# Altium schematic sheet analog_osc.SchDoc
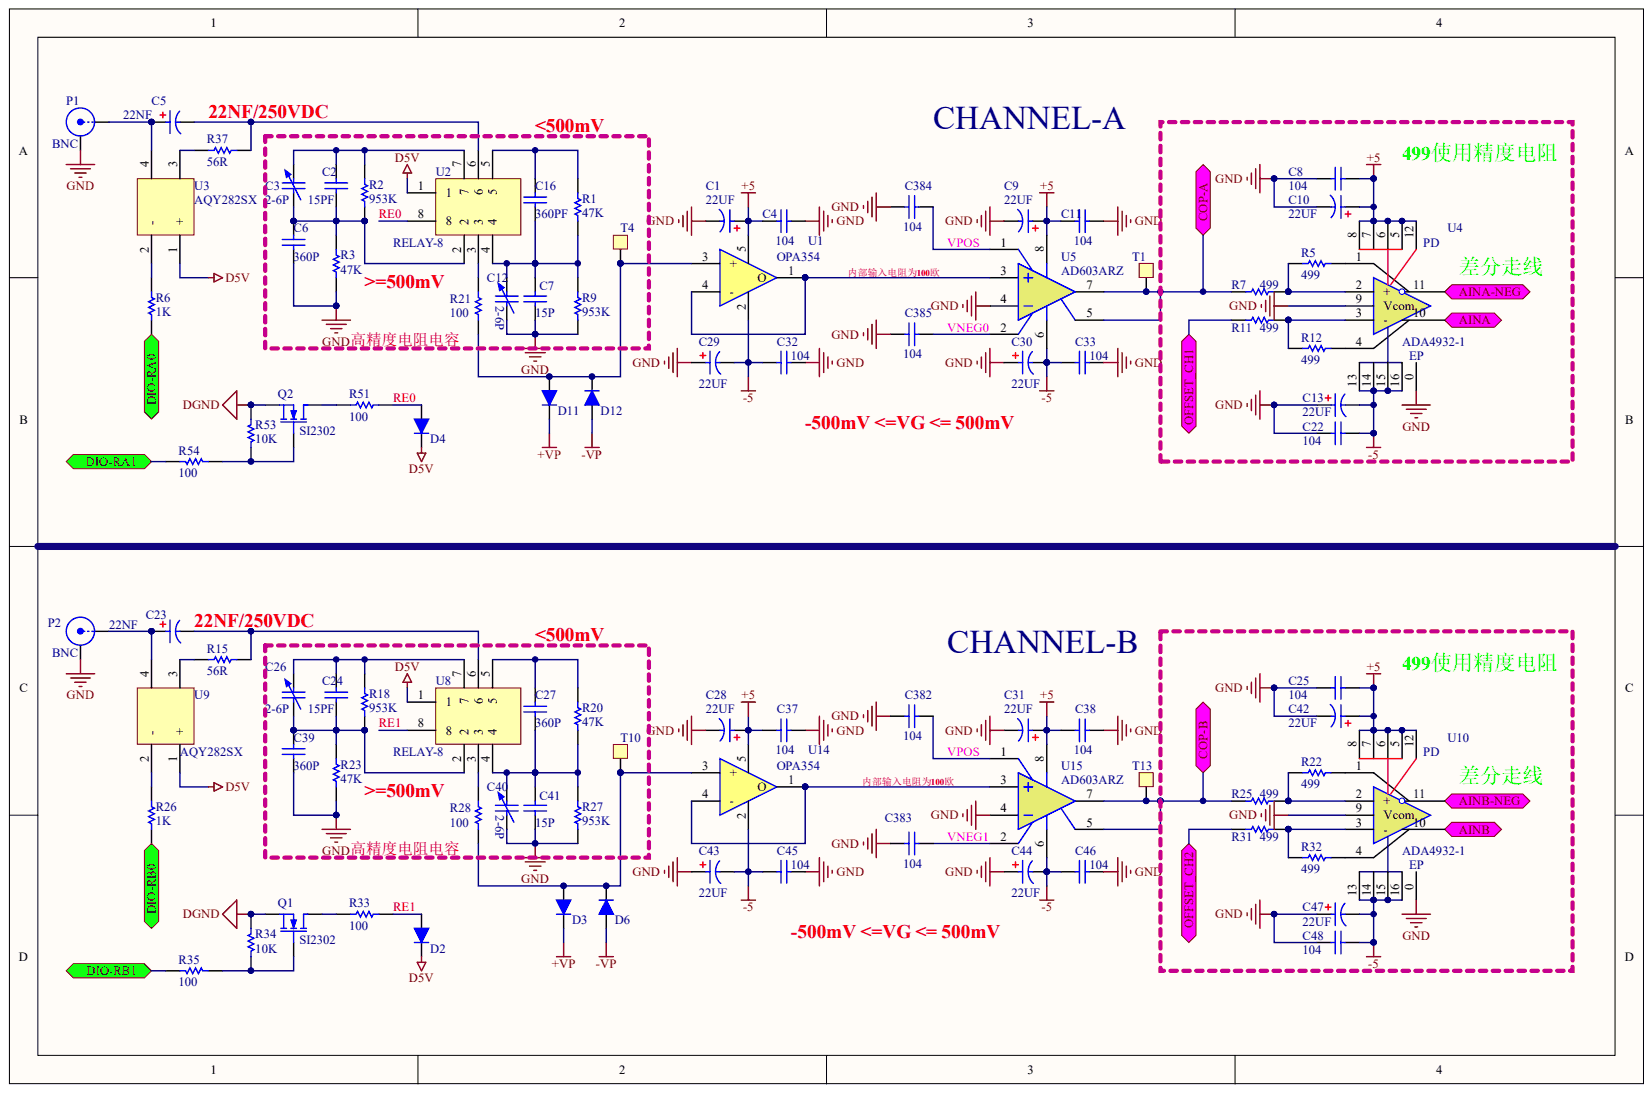
[diagram p0: analog_osc.SchDoc]

## Parts
C1  22UF
C2  15PF
C3  value 2-6P  (hidden comment: V_CAP)
C4  C104  (value not drawn)
C5  22NF
C6  360P
C7  15P
C8  C104  (value not drawn)
C9  22UF
C10  22UF
C11  C104  (value not drawn)
C12  value 2-6P  (hidden comment: V_CAP)
C13  22UF
C16  360PF
C22  C104  (value not drawn)
C23  22NF
C24  15PF
C25  C104  (value not drawn)
C26  value 2-6P  (hidden comment: V_CAP)
C27  360P
C28  22UF
C29  22UF
C30  22UF
C31  22UF
C32  C104  (value not drawn)
C33  C104  (value not drawn)
C37  C104  (value not drawn)
C38  C104  (value not drawn)
C39  360P
C40  value 2-6P  (hidden comment: V_CAP)
C41  15P
C42  22UF
C43  22UF
C44  22UF
C45  C104  (value not drawn)
C46  C104  (value not drawn)
C47  22UF
C48  C104  (value not drawn)
C382  C104  (value not drawn)
C383  C104  (value not drawn)
C384  C104  (value not drawn)
C385  C104  (value not drawn)
D2  BAT54WS  (value not drawn)
D3  KDS4148U  (value not drawn)
D4  BAT54WS  (value not drawn)
D6  KDS4148U  (value not drawn)
D11  KDS4148U  (value not drawn)
D12  KDS4148U  (value not drawn)
P1  BNC
P2  BNC
Q1  SI2302
Q2  SI2302
R1  47K
R2  953K
R3  47K
R5  499
R6  1K
R7  499
R9  953K
R11  499
R12  499
R15  56R
R18  953K
R20  47K
R21  R100R  (value not drawn)
R22  499
R23  47K
R25  499
R26  1K
R27  953K
R28  R100R  (value not drawn)
R31  499
R32  499
R33  R100R  (value not drawn)
R34  R10K  (value not drawn)
R35  R100R  (value not drawn)
R37  56R
R51  R100R  (value not drawn)
R53  R10K  (value not drawn)
R54  R100R  (value not drawn)
T1  TP  (value not drawn)
T4  TP  (value not drawn)
T10  TP  (value not drawn)
T13  TP  (value not drawn)
U1  OPA354
U2  RELAY-8
U3  AQY282SX
U4  ADA4932-1
U5  AD603ARZ
U8  RELAY-8
U9  AQY282SX
U10  ADA4932-1
U14  OPA354
U15  AD603ARZ

## Ports
AINA, AINA-NEG, AINB, AINB-NEG, COP-A, COP-B, DIO-RA0, DIO-RA1, DIO-RB0, DIO-RB1, OFFSET_CH1, OFFSET_CH2

## Nets
+5: C1.1 C4.1 C8.2 C9.1 C10.1 C11.1 C25.2 C28.1 C31.1 C37.1 C38.1 C42.1 U1.5 U4.5 U4.6 U4.7 U4.8 U4.12 U5.8 U10.5 U10.6 U10.7 U10.8 U10.12 U14.5 U15.8
+VP: D3.2 D11.2
-5: C13.2 C22.2 C29.2 C30.2 C32.1 C33.1 C43.2 C44.2 C45.1 C46.1 C47.2 C48.2 U1.2 U4.13 U4.14 U4.15 U4.16 U5.6 U10.13 U10.14 U10.15 U10.16 U14.2 U15.6
-VP: D6.1 D12.1
D5V: D2.2 D4.2 U2.1 U3.1(+) U8.1 U9.1(+)
DGND: Q1.2 Q2.2 R34.2 R53.2
GND: C1.2 C4.2 C6.2 C7.2 C8.1 C9.2 C10.2 C11.2 C12.1 C13.1 C22.1 C25.1 C28.2 C29.1 C30.1 C31.2 C32.2 C33.2 C37.2 C38.2 C39.2 C40.1 C41.2 C42.2 C43.1 C44.1 C45.2 C46.2 C47.1 C48.1 C382.1 C383.1 C384.1 C385.1 P1.2 P2.2 R3.2 R9.2 R23.2 R27.2 U4.0(EP) U4.9(Vcom) U5.4 U10.0(EP) U10.9(Vcom) U15.4
AINA: U4.10 ; port AINA
AINA-NEG: U4.11 ; port AINA-NEG
AINB: U10.10 ; port AINB
AINB-NEG: U10.11 ; port AINB-NEG
COP-A: R7.1 T1.1 U5.5 U5.7 ; port COP-A
COP-B: R25.1 T13.1 U15.5 U15.7 ; port COP-B
DIO-RA0: R6.1 ; port DIO-RA0
DIO-RA1: R54.2 ; port DIO-RA1
DIO-RB0: R26.1 ; port DIO-RB0
DIO-RB1: R35.2 ; port DIO-RB1
OFFSET_CH1: R11.1 ; port OFFSET_CH1
OFFSET_CH2: R31.1 ; port OFFSET_CH2
RE0: D4.1 R51.2 U2.8
RE1: D2.1 R33.2 U8.8
VNEG0: C385.2 U5.2
VNEG1: C383.2 U15.2
VPOS: C382.2 C384.2 U5.1 U15.1
NetC2_1: C2.1 C3.2 R2.1 U2.7
NetC2_2: C2.2 C3.1 C6.1 R2.2 R3.1 U2.2
NetC5_1: C5.1 P1.1 U3.4
NetC5_2: C5.2 R37.2 U2.6
NetC7_1: C7.1 C12.2 C16.2 R1.2 R9.1 U2.4
NetC16_1: C16.1 R1.1 U2.5
NetC23_1: C23.1 P2.1 U9.4
NetC23_2: C23.2 R15.2 U8.6
NetC24_1: C24.1 C26.2 R18.1 U8.7
NetC24_2: C24.2 C26.1 C39.1 R18.2 R23.1 U8.2
NetC27_1: C27.1 R20.1 U8.5
NetC27_2: C27.2 C40.2 C41.1 R20.2 R27.1 U8.4
NetD3_1: D3.1 D6.2 R28.2 T10.1 U14.3(+)
NetD11_1: D11.1 D12.2 R21.2 T4.1 U1.3(+)
NetQ1_1: Q1.1 R34.1 R35.1
NetQ1_3: Q1.3 R33.1
NetQ2_1: Q2.1 R53.1 R54.1
NetQ2_3: Q2.3 R51.1
NetR5_1: R5.1 R7.2 U4.2(+)
NetR5_2: R5.2 U4.1
NetR6_2: R6.2 U3.2(-)
NetR11_2: R11.2 R12.1 U4.3(-)
NetR12_2: R12.2 U4.4
NetR15_1: R15.1 U9.3
NetR21_1: R21.1 U2.3
NetR22_1: R22.1 R25.2 U10.2(+)
NetR22_2: R22.2 U10.1
NetR26_2: R26.2 U9.2(-)
NetR28_1: R28.1 U8.3
NetR31_2: R31.2 R32.1 U10.3(-)
NetR32_2: R32.2 U10.4
NetR37_1: R37.1 U3.3
NetU1_1: U1.1(O) U1.4(-) U5.3
NetU14_1: U14.1(O) U14.4(-) U15.3

## Library data of the parts
C1-C13 C16 C22-C33 C37-C48 C382-C385 D2-D4 D6 D11 D12 P1 P2 Q1 Q2 R1-R3 R5-R7 R9 R11 R12 R15 R18 R20-R23 R25-R28 R31-R35 R37 R51 R53 R54 T1 T4 T10 T13 U1-U5 U8-U10 U14 U15 = (no symbol name)
  C1 C9 C10 C13 C28-C31 C42-C44 C47: : 22UF
  C2 C24: : 15PF
  C3 C12 C26 C40: Published: 24-Mar-1999; LatestRevisionDate: 29-May-2009; LatestRevisionNote: IPC-7351 Footprint Added.; PackageReference: C1210; PackageDescription: Chip Capacitor 1210 Case Size; Code_JEITA: 1210; Code_IPC: CAPC3225
  C4 C8 C11 C22 C25 C32 C33 C37 C38 C45 C46 C48 C382-C385: : 104
  C5 C23: : 22NF
  C6 C27 C39: : 360P
  C7 C41: : 15P
  C16: : 360PF
  P1 P2: LatestRevisionDate: 17-Jul-2002; LatestRevisionNote: Re-released for DXP Platform.
  R1 R3 R20 R23: : 47K
  R2 R9 R18 R27: : 953K
  R5 R7 R11 R12 R22 R25 R31 R32: : 499
  R6 R26: : 1K
  R15 R37: : 56R
  R21 R28 R33 R35 R51 R54: : 100
  R34 R53: : 10K
  C3 C12 C26 C40 P1 P2: Publisher: Altium Limited
